# Altium schematic sheet ADCMultiplexerFrontEnd.SchDoc(ADCMultiplexerFrontEnd)
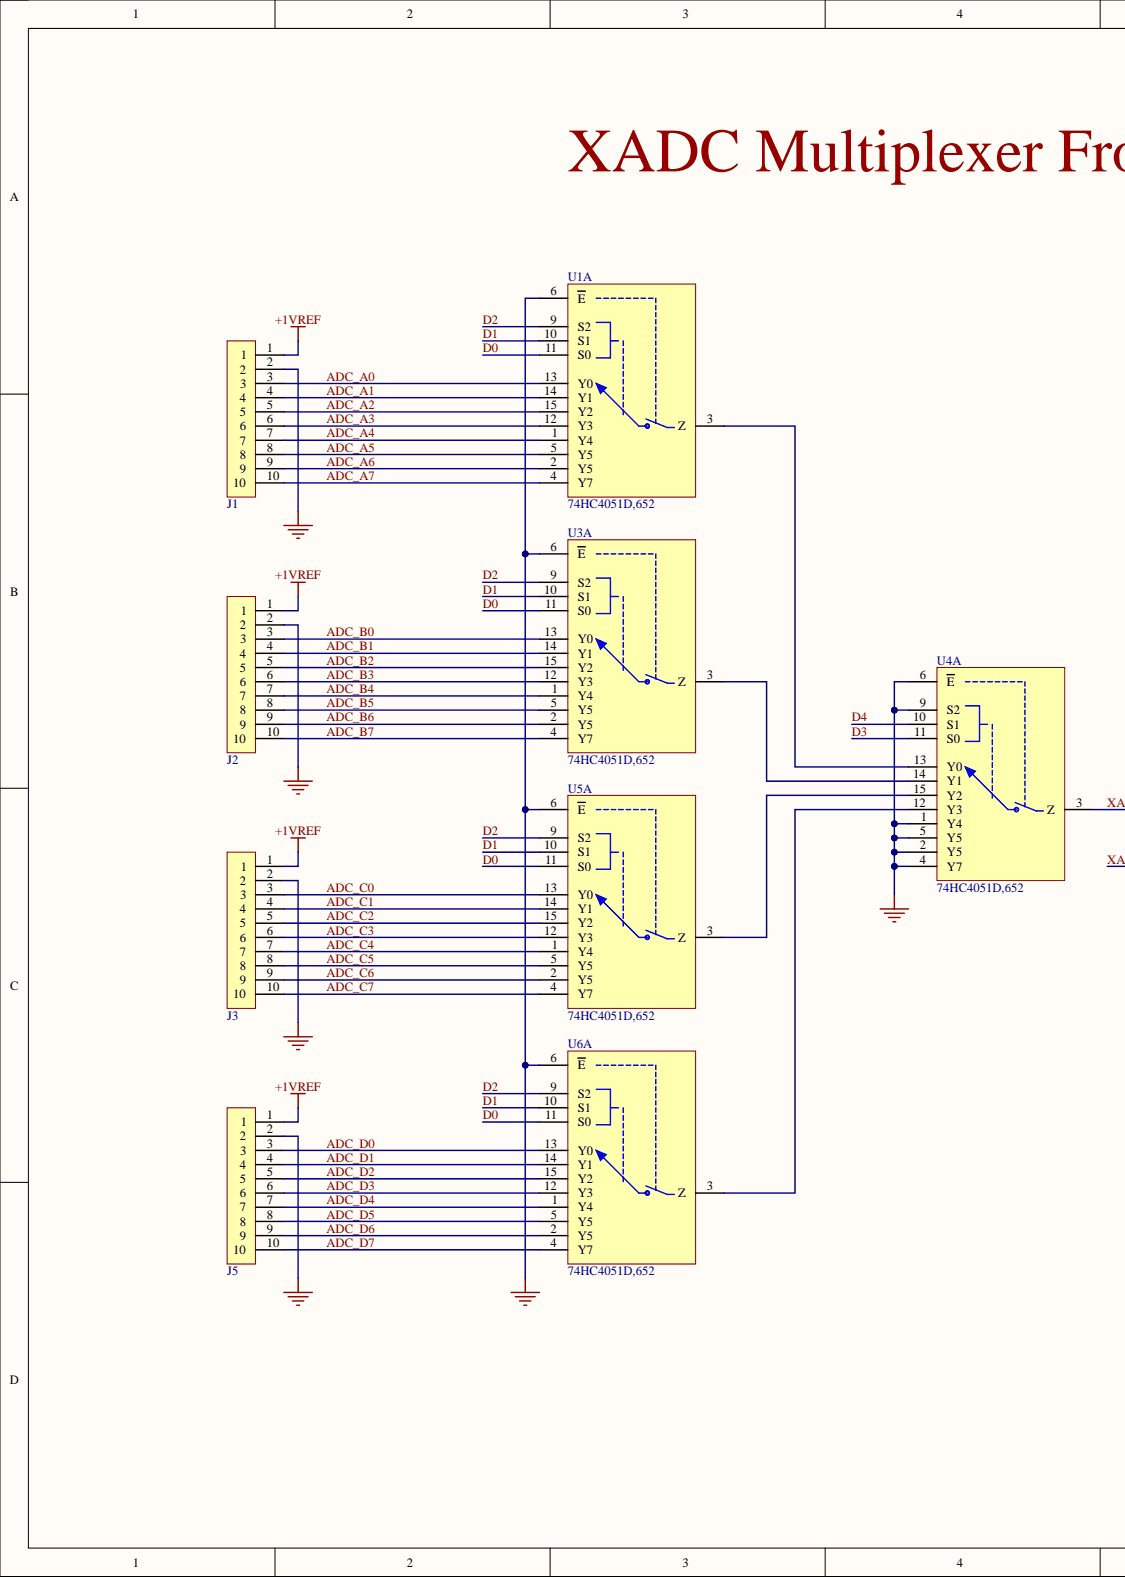
[diagram p0: ADCMultiplexerFrontEnd.SchDoc, left]
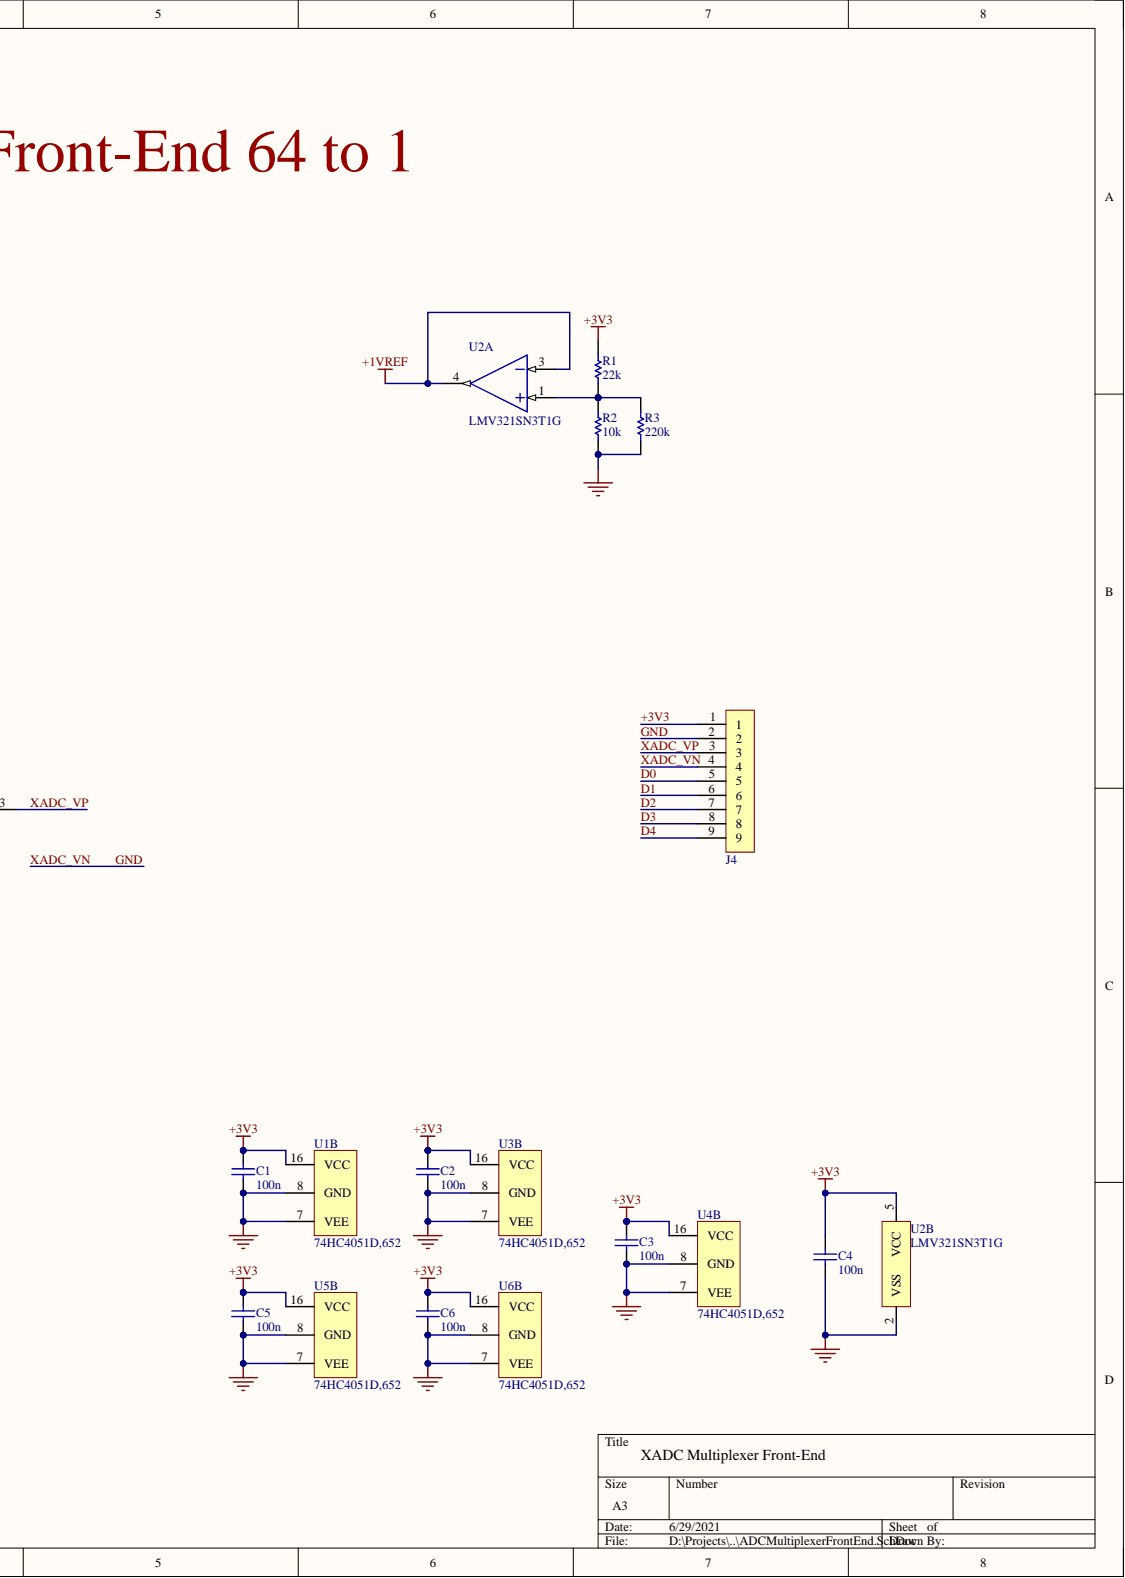
[diagram p1: ADCMultiplexerFrontEnd.SchDoc, right]

## Parts
C1  100n  [C0603]
C2  100n  [C0603]
C3  100n  [C0603]
C4  100n  [C0603]
C5  100n  [C0603]
C6  100n  [C0603]
J1  HDR M 1x8 2.54MM  [HDR M 1x10 2.54MM]  (value not drawn)
J2  HDR M 1x8 2.54MM  [HDR M 1x10 2.54MM]  (value not drawn)
J3  HDR M 1x8 2.54MM  [HDR M 1x10 2.54MM]  (value not drawn)
J4  HDR M 1x8 2.54MM  [HDR M 1x9 2.54MM]  (value not drawn)
J5  HDR M 1x8 2.54MM  [HDR M 1x10 2.54MM]  (value not drawn)
R1  22k  [R0805]
R2  10k  [R0805]
R3  220k  [R0805]
U1  74HC4051D,652  [SOIC16]  (parts A,B)
U2  LMV321SN3T1G  [TSOP5]  (parts A,B)
U3  74HC4051D,652  [SOIC16]  (parts A,B)
U4  74HC4051D,652  [SOIC16]  (parts A,B)
U5  74HC4051D,652  [SOIC16]  (parts A,B)
U6  74HC4051D,652  [SOIC16]  (parts A,B)

## Nets
+1VREF: J1.1 J2.1 J3.1 J5.1 U2.3 U2.4
+3V3: C1.2 C2.2 C3.2 C4.2 C5.2 C6.2 J4.1 R1.2 U1.16(VCC) U2.5(VCC) U3.16(VCC) U4.16(VCC) U5.16(VCC) U6.16(VCC)
ADC_A0: J1.3 U1.13(Y0)
ADC_A1: J1.4 U1.14(Y1)
ADC_A2: J1.5 U1.15(Y2)
ADC_A3: J1.6 U1.12(Y3)
ADC_A4: J1.7 U1.1(Y4)
ADC_A5: J1.8 U1.5(Y5)
ADC_A6: J1.9 U1.2(Y5)
ADC_A7: J1.10 U1.4(Y7)
ADC_B0: J2.3 U3.13(Y0)
ADC_B1: J2.4 U3.14(Y1)
ADC_B2: J2.5 U3.15(Y2)
ADC_B3: J2.6 U3.12(Y3)
ADC_B4: J2.7 U3.1(Y4)
ADC_B5: J2.8 U3.5(Y5)
ADC_B6: J2.9 U3.2(Y5)
ADC_B7: J2.10 U3.4(Y7)
ADC_C0: J3.3 U5.13(Y0)
ADC_C1: J3.4 U5.14(Y1)
ADC_C2: J3.5 U5.15(Y2)
ADC_C3: J3.6 U5.12(Y3)
ADC_C4: J3.7 U5.1(Y4)
ADC_C5: J3.8 U5.5(Y5)
ADC_C6: J3.9 U5.2(Y5)
ADC_C7: J3.10 U5.4(Y7)
ADC_D0: J5.3 U6.13(Y0)
ADC_D1: J5.4 U6.14(Y1)
ADC_D2: J5.5 U6.15(Y2)
ADC_D3: J5.6 U6.12(Y3)
ADC_D4: J5.7 U6.1(Y4)
ADC_D5: J5.8 U6.5(Y5)
ADC_D6: J5.9 U6.2(Y5)
ADC_D7: J5.10 U6.4(Y7)
D0: J4.5 U1.11(S0) U3.11(S0) U5.11(S0) U6.11(S0)
D1: J4.6 U1.10(S1) U3.10(S1) U5.10(S1) U6.10(S1)
D2: J4.7 U1.9(S2) U3.9(S2) U5.9(S2) U6.9(S2)
D3: J4.8 U4.11(S0)
D4: J4.9 U4.10(S1)
GND: C1.1 C2.1 C3.1 C4.1 C5.1 C6.1 J1.2 J2.2 J3.2 J4.2 J4.4 J5.2 R2.1 R3.1 U1.6(E) U1.7(VEE) U1.8(GND) U2.2(VSS) U3.6(E) U3.7(VEE) U3.8(GND) U4.1(Y4) U4.2(Y5) U4.4(Y7) U4.5(Y5) U4.6(E) U4.7(VEE) U4.8(GND) U4.9(S2) U5.6(E) U5.7(VEE) U5.8(GND) U6.6(E) U6.7(VEE) U6.8(GND)
XADC_VP: J4.3 U4.3(Z)
NetR1_1: R1.1 R2.2 R3.2 U2.1
NetU1_3: U1.3(Z) U4.13(Y0)
NetU3_3: U3.3(Z) U4.14(Y1)
NetU4_12: U4.12(Y3) U6.3(Z)
NetU4_15: U4.15(Y2) U5.3(Z)

## Library data of the parts
C1-C6 = C0603KC0G100V104: Component Kind: Standard; Library Name: UserLibrary.SchLib
J1-J3 J5 = HDR M 1x10 2.54MM: Component Kind: Standard; Library Name: UserLibrary.SchLib
J4 = HDR M 1x9 2.54MM: Component Kind: Standard; Library Name: UserLibrary.SchLib
R1 = R0805F22K: Component Kind: Standard; Library Name: UserLibrary.SchLib
R2 = R0805F10K: Component Kind: Standard; Library Name: UserLibrary.SchLib
R3 = R0805F220K: Component Kind: Standard; Library Name: UserLibrary.SchLib
U1 U3-U6 = 74HC4051D,652: IC MUX/DEMUX 8X1 16SOIC; Manufacturer: Nexperia; Category: Decoders and Multiplexers; Component Kind: Standard; ComponentLink1Description: https://assets.nexperia.com/documents/data-sheet/74HC_HCT4051.pdf?src-supplier=Digi-Key; ComponentLink1URL: https://assets.nexperia.com/documents/data-sheet/74HC_HCT4051.pdf?src-supplier=Digi-Key; Library Name: UserLibrary.SchLib; Manufacturer Part Number: 74HC4051D,652; Pricing 1: 1=0.52000, 70=0.49300, 100=0.47900, 250=0.43800, 500=0.42400 (USD); RoHS: Yes; RoHS Compliant: Yes; Stock 1: 155; Supplier 1: RSComponents; Supplier Part Number 1: 1697756
U2 = LMV321SN3T1G: ON SEMICONDUCTOR - LMV321SN3T1G - OP-AMP, SINGLE, 1MHZ, 1V/US, TSOP-5; Amplifier Type: GENERAL PURPOSE; Common Mode Rejection Ratio: 50dB; Input Bias Current: 1nA; Input Offset Voltage (Vos): 9mV; Max Supply Voltage: 5V; Min Supply Voltage: 2.7V; Nominal Supply Current: 250uA; Number of Channels: 1; Operating Supply Current: 130uA; Operating Supply Voltage: 3V; Output Current: 160mA; Slew Rate: 1V/µs; Voltage Gain: 100dB; Case/Package: TSOP; Category: Amplifiers - Op Amps, Buffer, Instrumentation; Component Kind: Standard; ComponentLink1Description: https://datasheet.ciiva.com/30481/0900766b81267f93-30481486.pdf?src-supplier=RSComponents; ComponentLink1URL: https://datasheet.ciiva.com/30481/0900766b81267f93-30481486.pdf?src-supplier=RSComponents; ComponentLink2Description: https://datasheet.ciiva.com/6627/lmv321-lmv358-lmv324-d-6627357.pdf?src-supplier=Digi-Key; ComponentLink2URL: https://datasheet.ciiva.com/6627/lmv321-lmv358-lmv324-d-6627357.pdf?src-supplier=Digi-Key; ComponentLink3Description: https://datasheet.ciiva.com/11710/lmv321-lmv358-lmv324-d-11710749.pdf?src-supplier=Avnet; ComponentLink3URL: https://datasheet.ciiva.com/11710/lmv321-lmv358-lmv324-d-11710749.pdf?src-supplier=Avnet; ComponentLink4Description: https://datasheet.ciiva.com/16456/lmv321-lmv358-lmv324-d-16456749.pdf?src-supplier=Future+Electronics; ComponentLink4URL: https://datasheet.ciiva.com/16456/lmv321-lmv358-lmv324-d-16456749.pdf?src-supplier=Future+Electronics; ComponentLink5Description: https://datasheet.ciiva.com/6627/lmv321-lmv358-lmv324-d-6627359.pdf?src-supplier=Digi-Key; ComponentLink5URL: https://datasheet.ciiva.com/6627/lmv321-lmv358-lmv324-d-6627359.pdf?src-supplier=Digi-Key; Contact Plating: Tin,Matte; Gain Bandwidth Product: 1MHz; Halogen Free: Halogen Free; Height: 1mm; Input Offset Voltage-Max: 9mV; Lead Free: Lead Free; Length: 3mm; Library Name: UserLibrary.SchLib; Manufacturer: ON Semiconductor; Manufacturer Part Number: LMV321SN3T1G; Max Operating Temperature: 85°C; Min Operating Temperature: -40°C; Nominal Gain Bandwidth Product: 1MHz; Number of Circuits: 1; Number of Elements: 1; Number of Pins: 5; Output Current per Channel: 160mA; Package Quantity: 3000; Packaging: Tape and Reel; Power Supply Rejection Ratio (PSRR): 50dB; Pricing 1: 20=0.11000, 100=0.21500, 1000=0.15900, 3000=0.14200, 9000=0.14000 (USD); Radiation Hardening: No; RoHS: Yes; RoHS Compliant: Yes; Series: -; Slew Rate-Nom: 1V/µs; Stock 1: 3580; Supplier 1: RSComponents; Supplier Part Number 1: 7878661; Supply Current: 250uA; Technology: CMOS; Unity Gain Bandwidth Product: 1MHz; Width: 1.5mm
